# Altium schematic sheet Power.SchDoc
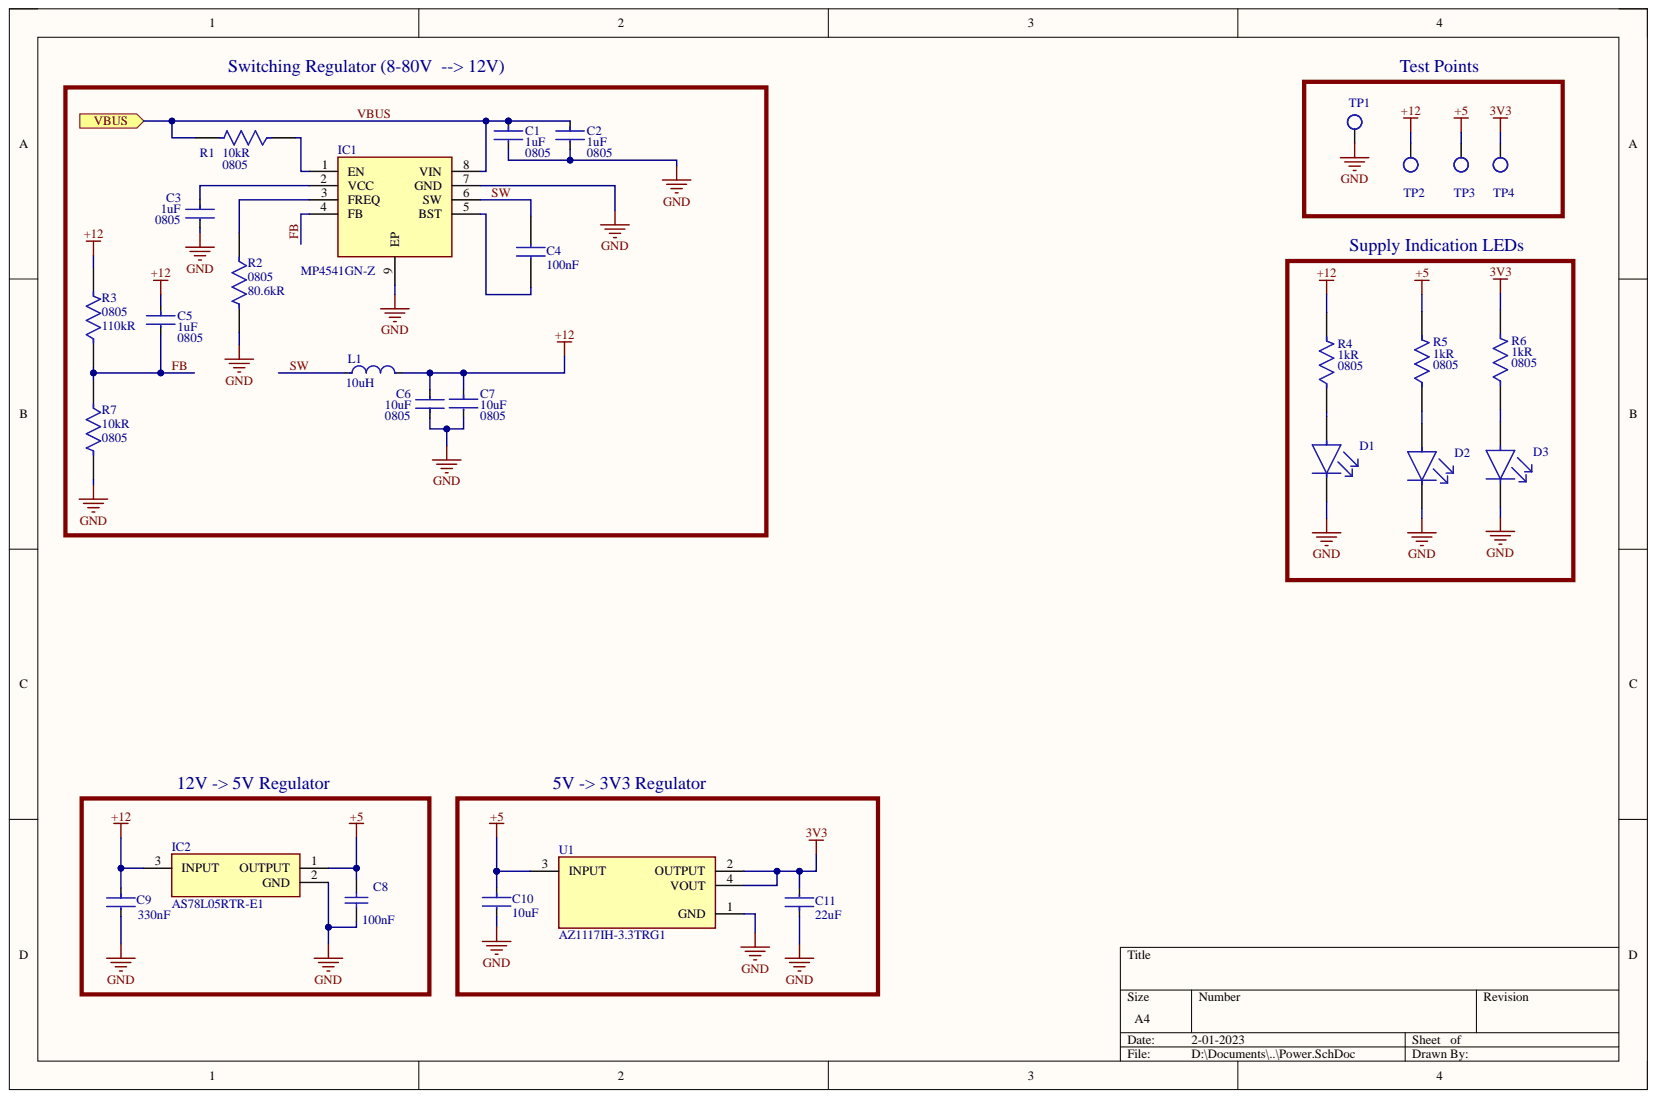
[diagram p0: Power.SchDoc]

## Parts
C1  Capacitance: 1uF  Case Code (Imperial): 0805  [FP-885012207078-MFG]  (hidden comment: 885012207078)
C2  Capacitance: 1uF  Case Code (Imperial): 0805  [FP-885012207078-MFG]  (hidden comment: 885012207078)
C3  Capacitance: 1uF  Case Code (Imperial): 0805  [FP-885012207078-MFG]  (hidden comment: 885012207078)
C4  Capacitance: 100nF  [C0805]  (hidden comment: C0805C104K1RACAUTO7210)
C5  Capacitance: 1uF  Case Code (Imperial): 0805  [FP-885012207078-MFG]  (hidden comment: 885012207078)
C6  Capacitance: 10uF  Case Code (Imperial): 0805  [FP-CL21-IPC_C]  (hidden comment: CL21A106KOQNNNG)
C7  Capacitance: 10uF  Case Code (Imperial): 0805  [FP-CL21-IPC_C]  (hidden comment: CL21A106KOQNNNG)
C8  Capacitance: 100nF  [CAPC2013X140X50ML20T25]  (hidden comment: 08055D104KAT2A)
C9  value 330nF  Capacitance: 330nF  [FP-CL21-F-IPC_C]  (hidden comment: CL21B334KBFNNNE)
C10  Capacitance: 10uF  [FP-CL21-IPC_B]  (hidden comment: CL21A106KOQNNNE)
C11  Capacitance: 22uF  [FP-CL21-IPC_B]  (hidden comment: CL21A226MQQNNNG)
D1  12V  [FP-150060VS75000-MFG]  (value not drawn)
D2  5V  [FP-150060VS75000-MFG]  (value not drawn)
D3  3V3  [FP-150060VS75000-MFG]  (value not drawn)
IC1  MP4541GN-Z  [SOIC127P600X170-9N]
IC2  AS78L05RTR-E1  [DIODES_SOT-89]
L1  Inductance: 10uH  [FP-LB2518-1_8-0_2-IPC_A]  (hidden comment: LBR2518T100K)
R1  Case Code (Imperial): 0805  Resistance: 10kR  [FP-RMCF0805-IPC_A]  (hidden comment: RMCF0805JT10K0)
R2  Case Code (Imperial): 0805  Resistance: 80.6kR  [FP-CRCW0805-e3-IPC_A]  (hidden comment: CRCW080580K6FKEA)
R3  Case Code (Imperial): 0805  Resistance: 110kR  [FP-RMCF0805-IPC_C]  (hidden comment: RMCF0805FT110K)
R4  Case Code (Imperial): 0805  Resistance: 1kR  [FP-RNCP0805-MFG]  (hidden comment: RNCP0805FTD1K00)
R5  Case Code (Imperial): 0805  Resistance: 1kR  [FP-RNCP0805-MFG]  (hidden comment: RNCP0805FTD1K00)
R6  Case Code (Imperial): 0805  Resistance: 1kR  [FP-RNCP0805-MFG]  (hidden comment: RNCP0805FTD1K00)
R7  Case Code (Imperial): 0805  Resistance: 10kR  [FP-RMCF0805-IPC_A]  (hidden comment: RMCF0805JT10K0)
TP1  GND  [TESTPOINT_LOOP]
TP2  12V  [TESTPOINT_LOOP]  (value not drawn)
TP3  5V  [TESTPOINT_LOOP]  (value not drawn)
TP4  3V3  [TESTPOINT_LOOP]
U1  AZ1117IH-3.3TRG1  [FP-SOT223-IPC_B]

## Ports
VBUS

## Nets
3V3: C11.2 R6.2 TP4.1 U1.2(OUTPUT) U1.4(VOUT)
+5: C8.2 C10.1 IC2.1 R5.2 TP3.1 U1.3(INPUT)
+12: C5.1 C6.2 C7.1 C9.1 IC2.3 L1.2 R3.2 R4.2 TP2.1
GND: C1.2 C2.2 C3.2 C6.1 C7.2 C8.1 C9.2 C10.2 C11.1 D1.1 D2.1 D3.1 IC1.7(GND) IC1.9(EP) IC2.2 R2.2 R7.2 TP1.1 U1.1(GND)
FB: C5.2 IC1.4(FB) R3.1 R7.1
SW: C4.2 IC1.6(SW) L1.1
VBUS: C1.1 C2.1 IC1.8(VIN) R1.2 ; port VBUS
NetC3_1: C3.1 IC1.2(VCC)
NetC4_1: C4.1 IC1.5(BST)
NetD1_2: D1.2 R4.1
NetD2_2: D2.2 R5.1
NetD3_2: D3.2 R6.1
NetIC1_1: IC1.1(EN) R1.1
NetIC1_3: IC1.3(FREQ) R2.1

## Library data of the parts
C1-C3 C5 = CMP-207839-000010-1: CAP CER 1UF 25V X7R 0805; Tolerance: 10%; Case Code (Metric): 2012; Case/Package: 0805; Component Kind: Standard; Dielectric: X7R; Length: 0.079inch; Library Name: Altium Content Vault; Max Operating Temperature: 125°C; Min Operating Temperature: -55°C; Mount: Surface Mount; Packaging: Cut Tape; RoHS Compliant: Yes; Series: WCAP-CSGP; Thickness: 0.053inch; Voltage Rating: 25V; Voltage Rating (DC): 25V; Width: 0.049inch
C4 = C0805C104K1RACAUTO7210: Capacitor; Manufacturer_Name: KEMET; Arrow Part Number: C0805C104K1RACAUTO7210; Arrow Price/Stock: https://www.arrow.com/en/products/c0805c104k1racauto7210/kemet-corporation?region=europe; Component Kind: Standard; Datasheet Link: https://content.kemet.com/datasheets/KEM_C1023_X7R_AUTO_SMD.pdf; Height: 1.1mm; Manufacturer_Part_Number: C0805C104K1RACAUTO7210; Mouser Part Number: 80-C0805C104K1RAUTLR; Mouser Price/Stock: https://www.mouser.co.uk/ProductDetail/KEMET/C0805C104K1RACAUTO7210?qs=NJR6qtu0rR34GE7CYHVK6Q%3D%3D; Library Name: WPT_Transmitter_lib.SchLib
C6 C7 = CMP-13271-002240-1: CL21 Series 0805 10uF 16V ±10% Tolerance X5R Multilayer Ceramic Chip Capacitor; Case Code (Metric): 2012; Case/Package: 0805; Component Kind: Standard; Depth: 1.25mm; Dielectric: X5R; Height: 1.25mm; Length: 2mm; Library Name: Altium Content Vault; Material: Ceramic; Max Operating Temperature: 85°C; Min Operating Temperature: -55°C; Mount: Surface Mount; Package Quantity: 3000; Packaging: Tape and Reel; Thickness: 0.053inch; Tolerance: 10%; Voltage: 16V; Voltage Rating: 16V; Width: 0.049inch
C8 = CMP-2007-04689-1: Manufacturer: AVX Interconnect / Elco; Component Kind: Standard; Library Name: Altium Content Vault; Part Number: 08055D104KAT2A
C9 = CMP-13271-000003-1: CAP CER 0.33UF 50V X7R 0805; Manufacturer: Samsung Electro-Mechanics; Material: Ceramic; Temperature Coefficient: 15%; Tolerance: 10%; Automotive: No; Category: Cap; Component Kind: Standard; ComponentLink2Description: Datasheet; ComponentLink2URL: http://www.samsungsem.com/kr/support/product-search/mlcc/__icsFiles/afieldfile/2018/07/24/CL21B334KBFNNNE.pdf; Device Class L1: Passive Components; Device Class L2: Capacitors; Device Class L3: Ceramic Capacitors; Digikey Description: CAP CER 0.33UF 50V X7R 0805; Height: 1.35mm; Ipc Land Pattern Name: CAPC200125X125; Lead Free: Yes; Library Name: Altium Content Vault; Manufacturer Part Number: CL21B334KBFNNNE; Octopart: https://octopart.com/cl21b334kbfnnne-samsung-9287149; Package: CL21-F; RoHS: TRUE; Temperature: -55°C to +125°C; Temperature Characteristic: X7R; Temperature Range High: +125°C; Temperature Range Low: -55°C; Voltage Rating: 50V
C10 = CMP-2000-06102-2: CL21 Series 0805 10uF 16V ±10% Tolerance X5R Multilayer Ceramic Chip Capacitor; Dielectric: X7R; Case Code (Imperial): 0805; Case Code (Metric): 2012; Case/Package: 0805; Component Kind: Standard; Depth: 1.25mm; Height: 1.25mm; Lead Free: Lead Free; Length: 2mm; Library Name: Altium Content Vault; Max Operating Temperature: 85°C; Min Operating Temperature: -55°C; Mount: Surface Mount; Package Quantity: 1; Packaging: Tape and Reel; Radiation Hardening: No; Thickness: 0.055inch; Tolerance: 10%; Voltage: 16V; Voltage Rating: 16V; Voltage Rating (DC): 16V; Width: 0.049inch
C11 = CMP-13271-002967-1: CL21 Series 0805 22uF 6.3V ±20% Tolerance X5R Multilayer Ceramic Chip Capacitor; Case Code (Imperial): 0805; Case Code (Metric): 2012; Case/Package: 0805; Component Kind: Standard; Depth: 1.25mm; Dielectric: X5R; Height: 1.25mm; Length: 2mm; Library Name: Altium Content Vault; Material: Ceramic; Max Operating Temperature: 85°C; Min Operating Temperature: -55°C; Mount: Surface Mount; Package Quantity: 3000; Packaging: Tape and Reel; Radiation Hardening: No; Thickness: 0.055inch; Tolerance: 20%; Voltage: 6.3V; Voltage Rating: 6.3V; Width: 0.049inch
D1 D3 = CMP-1426-00006-5: LED GREEN CLEAR 0603 SMD; Dominant Wavelength: 570nm; Forward Current: 20mA; Forward Voltage: 2V; Lens Color: Colorless; Lens Size: 0.7mm; Lens Style: Rectangular; Luminous Intensity: 40mcd; Power Rating: 72mW; Reverse Voltage (DC): 5V; Viewing Angle: 140°; Case Code (Imperial): 0603; Case Code (Metric): 1608; Case/Package: 0603; Color: Green; Component Kind: Standard; Height: 0.8mm; Illumination Color: Green; Length: 1.6mm; Lens Transparency: Clear; Library Name: Altium Content Vault; Max Operating Temperature: 85°C; Min Operating Temperature: -40°C; Mount: Surface Mount; Number of Pins: 2; Package Quantity: 4000; Packaging: Cut Tape; RoHS Compliant: Yes; Series: WL-SMCW; Test Current: 20mA; Wavelength: 570nm; Weight: 0.000882oz; Width: 0.8mm
D2 = CMP-1426-00006-5: LED GREEN CLEAR 0603 SMD; Dominant Wavelength: 570nm; Forward Current: 20mA; Forward Voltage: 2V; Lens Color: Colorless; Lens Size: 0.7mm; Lens Style: Rectangular; Luminous Intensity: 40mcd; Power Rating: 72mW; Viewing Angle: 140°; Case Code (Imperial): 0603; Case Code (Metric): 1608; Case/Package: 0603; Color: Green; Component Kind: Standard; Height: 0.8mm; Illumination Color: Green; Length: 1.6mm; Lens Transparency: Clear; Library Name: Altium Content Vault; Max Operating Temperature: 85°C; Min Operating Temperature: -40°C; Mount: Surface Mount; Number of Pins: 2; Package Quantity: 4000; Packaging: Cut Tape; Reverse Voltage (DC): 5V; RoHS Compliant: Yes; Series: WL-SMCW; Test Current: 20mA; Wavelength: 570nm; Weight: 0.000882oz; Width: 0.8mm
IC1 = MP4541GN-Z: Integrated Circuit; Manufacturer_Name: Monolithic Power Systems (MPS); Component Kind: Standard; Datasheet Link: https://www.monolithicpower.com/en/documentview/productdocument/index/version/2/document_type/Datasheet/lang/en/sku/MP4541GN/; Height: 1.7mm; Manufacturer_Part_Number: MP4541GN-Z; Mouser Part Number: 946-MP4541GN-Z; Mouser Price/Stock: https://www.mouser.co.uk/ProductDetail/Monolithic-Power-Systems-MPS/MP4541GN-Z?qs=TCDPyi3sCW3JrMFxnpK4AA%3D%3D; Library Name: WPT_Transmitter_lib.SchLib
IC2 = AS78L05RTR-E1: Integrated Circuit; Manufacturer_Name: Diodes Inc.; Arrow Part Number: AS78L05RTR-E1; Arrow Price/Stock: https://www.arrow.com/en/products/as78l05rtr-e1/diodes-incorporated?region=asia; Component Kind: Standard; Datasheet Link: https://www.diodes.com/assets/Datasheets/AS78Lxx.pdf; Manufacturer_Part_Number: AS78L05RTR-E1; Mouser Part Number: 621-AS78L05RTR-E1; Mouser Price/Stock: https://www.mouser.co.uk/ProductDetail/Diodes-Incorporated/AS78L05RTR-E1?qs=FKu9oBikfSksfBIasZ7FtQ%3D%3D; Library Name: WPT_Transmitter_lib.SchLib
L1 = CMP-14484-000483-1: SMD Inductors 10uH ±10% 0.235A 0.247Ω 1007; Core Material: Ferrite; Current Rating: 235mA; DC Resistance (DCR): 190mR; Self Resonant Frequency: 30MHz; Shielding: Unshielded; Test Frequency: 2.52MHz; Case Code (Imperial): 1007; Case Code (Metric): 2518; Case/Package: 1007; Component Kind: Standard; DC Current: 235mA; Depth: 2mm; Halogen Free: Halogen Free; Height: 1.8mm; Height - Seated (Max): 0.079inch; Inductance Tolerance: 10%; Lead Free: Lead Free; Lead Pitch: 1.5mm; Length: 0.098inch; Library Name: Altium Content Vault; Max DC Current: 235mA; Max Operating Temperature: 105°C; Military Standard: Not; Min Operating Temperature: -40°C; Mount: Surface Mount; Package Quantity: 2000; Packaging: Tape and Reel; Radiation Hardening: No; Resistor Type: Wirewound; RoHS Compliant: Yes; Series: LB; Termination: SMD/SMT; Tolerance: 10%; Width: 0.071inch
R1 R7 = CMP-26527-002663-1: 10kΩ ±5% 0.125W 0805 Thick Film Chip Resistor AEC-Q200 compliant; Max Power Dissipation: 125mW; Temperature Coefficient: 100ppm/°C; Case Code (Metric): 2012; Case/Package: 0805; Component Kind: Standard; Construction: Rectangular; Contact Plating: Tin; Depth: 1.25mm; Features: Automotive AEC-Q200; Height: 0.026inch; Lead Free: Lead Free; Length: 2mm; Library Name: Altium Content Vault; Max Operating Temperature: 155°C; Military Standard: Not; Min Operating Temperature: -55°C; Mount: Surface Mount; Number of Terminations: 2; Packaging: Tape and Reel; Power Rating: 125mW; Radiation Hardening: No; Resistor Type: Thick Film; RoHS Compliant: Yes; Series: RMCF; Size Code: 0805; Tolerance: 5%; Width: 0.049inch
R2 = CMP-2001-04127-2: RES Thick Film, 80.6kΩ, 1%, 0.125W, 100ppm/°C, 0805; Max Power Dissipation: 125mW; Voltage Rating: 150V; Case Code (Metric): 2012; Case/Package: 0805; Component Kind: Standard; Composition: Thick Film; Contact Plating: Tin; Depth: 1.25mm; Features: Automotive AEC-Q200; Height: 0.45mm; Lead Free: Lead Free; Length: 2mm; Library Name: Altium Content Vault; Max Operating Temperature: 155°C; Military Standard: Not; Min Operating Temperature: -55°C; Number of Pins: 2; Number of Terminations: 2; Package Quantity: 10; Packaging: Tape and Reel; Power Rating: 125mW; Radiation Hardening: No; Temperature Coefficient: 100ppm/°C; Termination: Solder; Tolerance: 1%; Voltage Rating (DC): 150V; Weight: 0.000194oz; Width: 1.25mm
R3 = CMP-26527-000712-1: 110kΩ ±1% 0.125W 0805 Thick Film Chip Resistor AEC-Q200 compliant; Max Power Dissipation: 125mW; Temperature Coefficient: 100ppm/°C; Case Code (Metric): 2012; Case/Package: 0805; Component Kind: Standard; Contact Plating: Tin; Features: Automotive AEC-Q200; Height: 0.026inch; Lead Free: Lead Free; Length: 0.079inch; Library Name: Altium Content Vault; Max Operating Temperature: 155°C; Min Operating Temperature: -55°C; Mount: Surface Mount; Number of Terminations: 2; Packaging: Tape and Reel; Power Rating: 125mW; Radiation Hardening: No; Resistor Type: Thick Film; RoHS Compliant: Yes; Series: RMCF; Size Code: 0805; Tolerance: 1%; Width: 0.049inch
R4-R6 = CMP-26527-001902-1: 1kΩ ±1% 0.25W 0805 High Power Anti-Sulfur Thin Film Chip Resistor; Max Power Dissipation: 250mW; Temperature Coefficient: 100ppm/°C; Case Code (Metric): 2012; Case/Package: 0805; Component Kind: Standard; Features: Moisture Resistant; Height: 0.024inch; Lead Free: Lead Free; Length: 0.079inch; Library Name: Altium Content Vault; Max Operating Temperature: 155°C; Min Operating Temperature: -55°C; Mount: Surface Mount; Number of Terminations: 2; Packaging: Tape and Reel; Power Rating: 250mW; Resistor Type: Thin Film; RoHS Compliant: Yes; Series: RNCP; Size Code: 0805; Tolerance: 1%; Width: 0.049inch
TP1-TP4 = TESTPOINT_LOOP: PC TEST POINT MINIATURE WHITE; Manufacturer 1: Keystone Electronics; Manufacturer Part Number 1: 5002; Component Kind: Standard; Library Name: Connectors and Headers.IntLib; Mount: Through Hole; Supplier 1: Digi-Key; Supplier Part Number 1: 36-5002-ND
U1 = CMP-12756-000043-1: IC REG LINEAR 3.3V 1A SOT223; Dropout Voltage: 1.2V; Number of Regulators: 1; Output Current: 800mA; Case/Package: TO-261-4; Component Kind: Standard; Library Name: Altium Content Vault; Max Operating Temperature: 125°C; Min Current Limit: 1A; Min Operating Temperature: -40°C; Mount: Surface Mount; Number of Pins: 3; Output Voltage: 3.3V; Packaging: Tape and Reel; RoHS Compliant: Yes
